# Altium schematic sheet MCU.SchDoc(MCU)
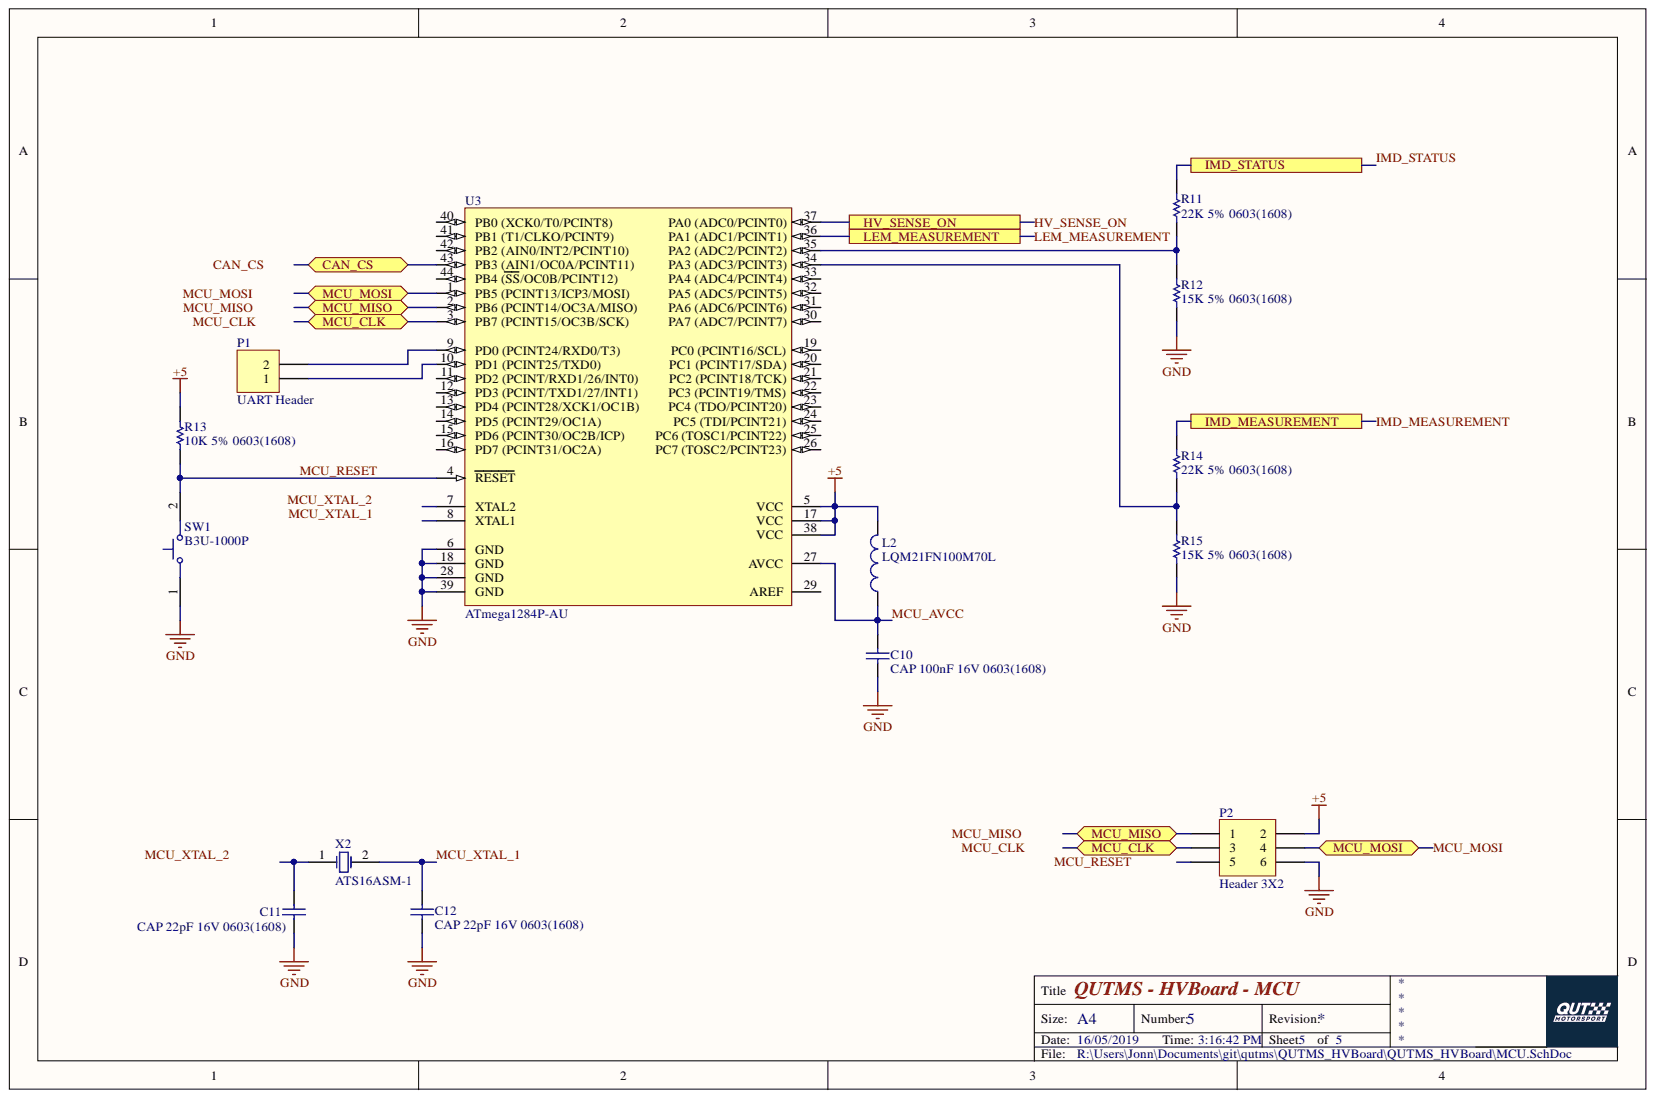
[diagram p0: MCU.SchDoc]

## Parts
C10  CAP 100nF 16V 0603(1608)  value 100nF  [CAPC0603(1608)100_M]
C11  CAP 22pF 16V 0603(1608)  value 22pF  [CAPC0603(1608)100_L]
C12  CAP 22pF 16V 0603(1608)  value 22pF  [CAPC0603(1608)100_L]
L2  LQM21FN100M70L  [MURA-IND0805_L]
P1  UART Header  [HDR1X2]
P2  Header 3X2  [HDR2X3]
R11  22K 5% 0603(1608)  [RESC0603(1608)_M]
R12  15K 5% 0603(1608)  [RESC0603(1608)_M]
R13  10K 5% 0603(1608)  [RESC0603(1608)_N]
R14  22K 5% 0603(1608)  [RESC0603(1608)_M]
R15  15K 5% 0603(1608)  [RESC0603(1608)_M]
SW1  B3U-1000P  [OMRON-B3U-1000_V]
U3  ATmega1284P-AU  [44A_N]
X2  ATS16ASM-1  [CTS-ATS-SM-XTAL-2]

## Ports
CAN_CS, HV_SENSE_ON, IMD_MEASUREMENT, IMD_STATUS, LEM_MEASUREMENT, MCU_CLK, MCU_MISO, MCU_MOSI

## Nets
+5: L2.2 P2.2 R13.2 U3.5(VCC) U3.17(VCC) U3.38(VCC)
GND: C10.1 C11.1 C12.1 P2.6 R12.1 R15.1 SW1.1 U3.6(GND) U3.18(GND) U3.28(GND) U3.39(GND)
CAN_CS: U3.43(PB3_(AIN1/OC0A/PCINT11)) ; port CAN_CS
HV_SENSE_ON: U3.37(PA0_(ADC0/PCINT0)) ; port HV_SENSE_ON
IMD_MEASUREMENT: R14.2 ; port IMD_MEASUREMENT
IMD_STATUS: R11.2 ; port IMD_STATUS
LEM_MEASUREMENT: U3.36(PA1_(ADC1/PCINT1)) ; port LEM_MEASUREMENT
MCU_AVCC: C10.2 L2.1 U3.27(AVCC)
MCU_CLK: P2.3 U3.3(PB7_(PCINT15/OC3B/SCK)) ; port MCU_CLK
MCU_MISO: P2.1 U3.2(PB6_(PCINT14/OC3A/MISO)) ; port MCU_MISO
MCU_MOSI: P2.4 U3.1(PB5_(PCINT13/ICP3/MOSI)) ; port MCU_MOSI
MCU_RESET: P2.5 R13.1 SW1.2 U3.4(RESET)
MCU_XTAL_1: C12.2 U3.8(XTAL1) X2.2
MCU_XTAL_2: C11.2 U3.7(XTAL2) X2.1
NetP1_1: P1.1 U3.10(PD1_(PCINT25/TXD0))
NetP1_2: P1.2 U3.9(PD0_(PCINT24/RXD0/T3))
NetR11_1: R11.1 R12.2 U3.35(PA2_(ADC2/PCINT2))
NetR14_1: R14.1 R15.2 U3.34(PA3_(ADC3/PCINT3))

Not connected: U3 11(PD2_(PCINT/RXD1/26/INT0)) 12(PD3_(PCINT/TXD1/27/INT1)) 13(PD4_(PCINT28/XCK1/OC1B)) 14(PD5_(PCINT29/OC1A)) 15(PD6_(PCINT30/OC2B/ICP)) 16(PD7_(PCINT31/OC2A)) 19(PC0_(PCINT16/SCL)) 20(PC1_(PCINT17/SDA)) 21(PC2_(PCINT18/TCK)) 22(PC3_(PCINT19/TMS)) 23(PC4_(TDO/PCINT20)) 24(PC5_(TDI/PCINT21)) 25(PC6_(TOSC1/PCINT22)) 26(PC7_(TOSC2/PCINT23)) 29(AREF) 30(PA7_(ADC7/PCINT7)) 31(PA6_(ADC6/PCINT6)) 32(PA5_(ADC5/PCINT5)) 33(PA4_(ADC4/PCINT4)) 40(PB0_(XCK0/T0/PCINT8)) 41(PB1_(T1/CLKO/PCINT9)) 42(PB2_(AIN0/INT2/PCINT10)) 44(PB4_(SS/OC0B/PCINT12))

## Library data of the parts
C10 = CMP-1035-02583-1: CAP 100nF 16V ±5% 0603 (1608 Metric) Thickness 1mm SMD; Tolerance: Â±5%; Case-Metric: 1608; Case-EIA: 0603; Technology: SMT; Rated Voltage: 16 V; Max Thickness: 1 mm; Library Name: Altium Content Vault; Component Kind: Standard
C11 C12 = CMP-1035-00806-1: CAP 22pF 16V ±1% 0603 (1608 Metric) Thickness 1mm SMD; Tolerance: Â±1%; Case-Metric: 1608; Case-EIA: 0603; Technology: SMT; Rated Voltage: 16 V; Max Thickness: 1 mm; Library Name: Altium Content Vault; Component Kind: Standard
L2 = CMP-2000-06587-1: Fixed Inductor, 10 uH, +/-20%, -55 to 125 degC, 2-Pin SMD (0805), RoHS, Tape and Reel; Manufacturer: Murata; Tolerance (%): 20%; Rated Current-Max: 100mA; Inductance-Nom: 10H; DC Resistance: 0.6; Package Reference: IND0805; Package Description: 2-Pin Surface Mount Device, Body 2 x 1.25 mm; Mounting Technology: SurfaceMount; Manufacturer URL: http://www.murata.com/; Library Name: Altium Content Vault; Datasheet Version: 05/2016; Datasheet URL: http://psearch.en.murata.com/inductor/product/LQM21FN100M70%23.pdf; Component Kind: Standard; Case Size/Dimension: 0805
P1 = Header 2: Signal Integrity: Connector; Library Name: Miscellaneous Connectors.IntLib; LatestRevisionNote: Re-released for DXP Platform.; LatestRevisionDate: 17-Jul-2002; Description: UART Header; Component Kind: Standard; Publisher: Altium Limited
P2 = Header 3X2: Header, 3-Pin, Dual row; Signal Integrity: Connector; Library Name: Miscellaneous Connectors.IntLib; LatestRevisionNote: Re-released for DXP Platform.; LatestRevisionDate: 17-Jul-2002; Component Kind: Standard; Publisher: Altium Limited
R11 R14 = CMP-1012-00106-1: 22K 0.1W 5% 0603 (1608 Metric)  SMD; Value: 22000.00 Ohm; Case-Metric: 1608; Case-EIA: 0603; Tolerance: 5 %; Technology: SMT; Power: 0.1 W; Library Name: Altium Content Vault; Component Kind: Standard
R12 R15 = CMP-1012-00102-1: 15K 0.1W 5% 0603 (1608 Metric)  SMD; Value: 15000.00 Ohm; Case-Metric: 1608; Case-EIA: 0603; Tolerance: 5 %; Technology: SMT; Power: 0.1 W; Library Name: Altium Content Vault; Component Kind: Standard
R13 = CMP-1012-00098-1: 10K 0.1W 5% 0603 (1608 Metric)  SMD; Value: 10000.00 Ohm; Case-Metric: 1608; Case-EIA: 0603; Tolerance: 5 %; Technology: SMT; Power: 0.1 W; Library Name: Altium Content Vault; Component Kind: Standard
SW1 = CMP-2100-03586-1: Ultra-Small Tactile Switch, 0.05 A, 12 V, -25 to 70 degC, 2-Pin SMD, RoHS, Tape and Reel; Manufacturer: Omron Electronic Components; Voltage Rating (DC): 12V; Throw Configuration: SPST; Switch Travel: 0.15mm; Operating Force: 1.5N; Max Current Rating: 50mA; Insulation Resistance: 100MOhm; Illumination Type: Illuminated; Electrical Life: 200000Cycles; Actuator Length: 0.4mm; Width: 3mm; Weight: 0.022g; Termination: SMD/SMT; RoHSCompliant: true; REACH SVHC: Unknown; Packaging: TapeandReel; Package Reference: B3U-1000; Package Quantity: 1; Package Description: 2-Pin Surface Mount Device, Body 3 x 2.5 mm; Orientation: Straight; Mount: SurfaceMount; Min Operating Temperature: -25degC; Max Operating Temperature: 70degC; Manufacturer URL: http://www.omron.com/; Library Name: Altium Content Vault; Length: 4mm; Lead Free: LeadFree; Height: 1.2mm; Depth: 2.5mm; Datasheet Version: 42005.0; Datasheet URL: http://www.omron.com/ecb/products/pdf/en-b3u.pdf; Current Rating: 50mA; Contact Material: Silver; Contact Current Rating: 50mA; Component Kind: Standard; Case/Package: SMD/SMT
U3 = CMP-0095-00577-1: 8-bit AVR Microcontroller, 128KB Flash, 4KB EEPROM, 16KB SRAM, 44-pin TQFP, Industrial Grade (-40°C to 85°C); PackageVersion: revC, Oct-2010; PackageReference: 44A; PackageDescription: 44-Pin Plastic Thin Profile Quad Flat Pack, 10 x 10 x 1.0 mm Body, 0.8 mm Pitch (TQFP); Library Name: Altium Content Vault; DatasheetVersion: revC, Jun-2011; ComponentLink3URL: http://www.atmel.com/dyn/resources/prod_documents/8272S.pdf; ComponentLink3Description: ATmega164A/164PA/324A/324PA/644A/644PA/1284/1284P Summary; ComponentLink2URL: http://www.atmel.com/dyn/resources/prod_documents/doc8272.pdf; ComponentLink2Description: ATmega164A/164PA/324A/324PA/644A/644PA/1284/1284P Datasheet; ComponentLink1URL: http://www.atmel.com/; ComponentLink1Description: Manufacturer URL; Component Kind: Standard; Code_JEDEC: MS-026-ACB
X2 = CMP-0585-00002-2: ATS-SM Series Quartz Crystal, 16 MHz, -20 to 70 degC, 11.1 x 4.83 x 4.3mm SMD, RoHS, Tape and Reel; Manufacturer: CTS Corporation; Shunt Capacitance (C0): 7.0 pF Max; Oscillation Mode: Fundamental or 3rd Overtone; Frequency: 16 MHz; ESR Maximum: 40 Ohms; Drive Level (Type): 100 uW; Crystal Cut: AT-Cut; RoHS: TRUE; PartNumber: ATS16ASM-1; Packing: Tape and Reel; PackageReference: ATS-SM-XTAL-2; PackageDescription: 2-Pin SMD, Body 11.1 x 4.83 mm, Pitch 8.5 mm; Operating Temperature: -20 to 70 degC; Mounting Technology: Surface Mount; Library Name: Altium Content Vault; DatasheetVersion: Rev. K; ComponentLink3URL: http://www.ctscorp.com/components/Datasheets/008-0309-0.pdf; ComponentLink3Description: Package Specification; ComponentLink2URL: http://www.ctscorp.com/components/Datasheets/008-0309-0.pdf; ComponentLink2Description: Datasheet; ComponentLink1URL: http://www.ctscorp.com/; ComponentLink1Description: Manufacturer URL; Component Kind: Standard
